# Altium schematic sheet Buttons.SchDoc
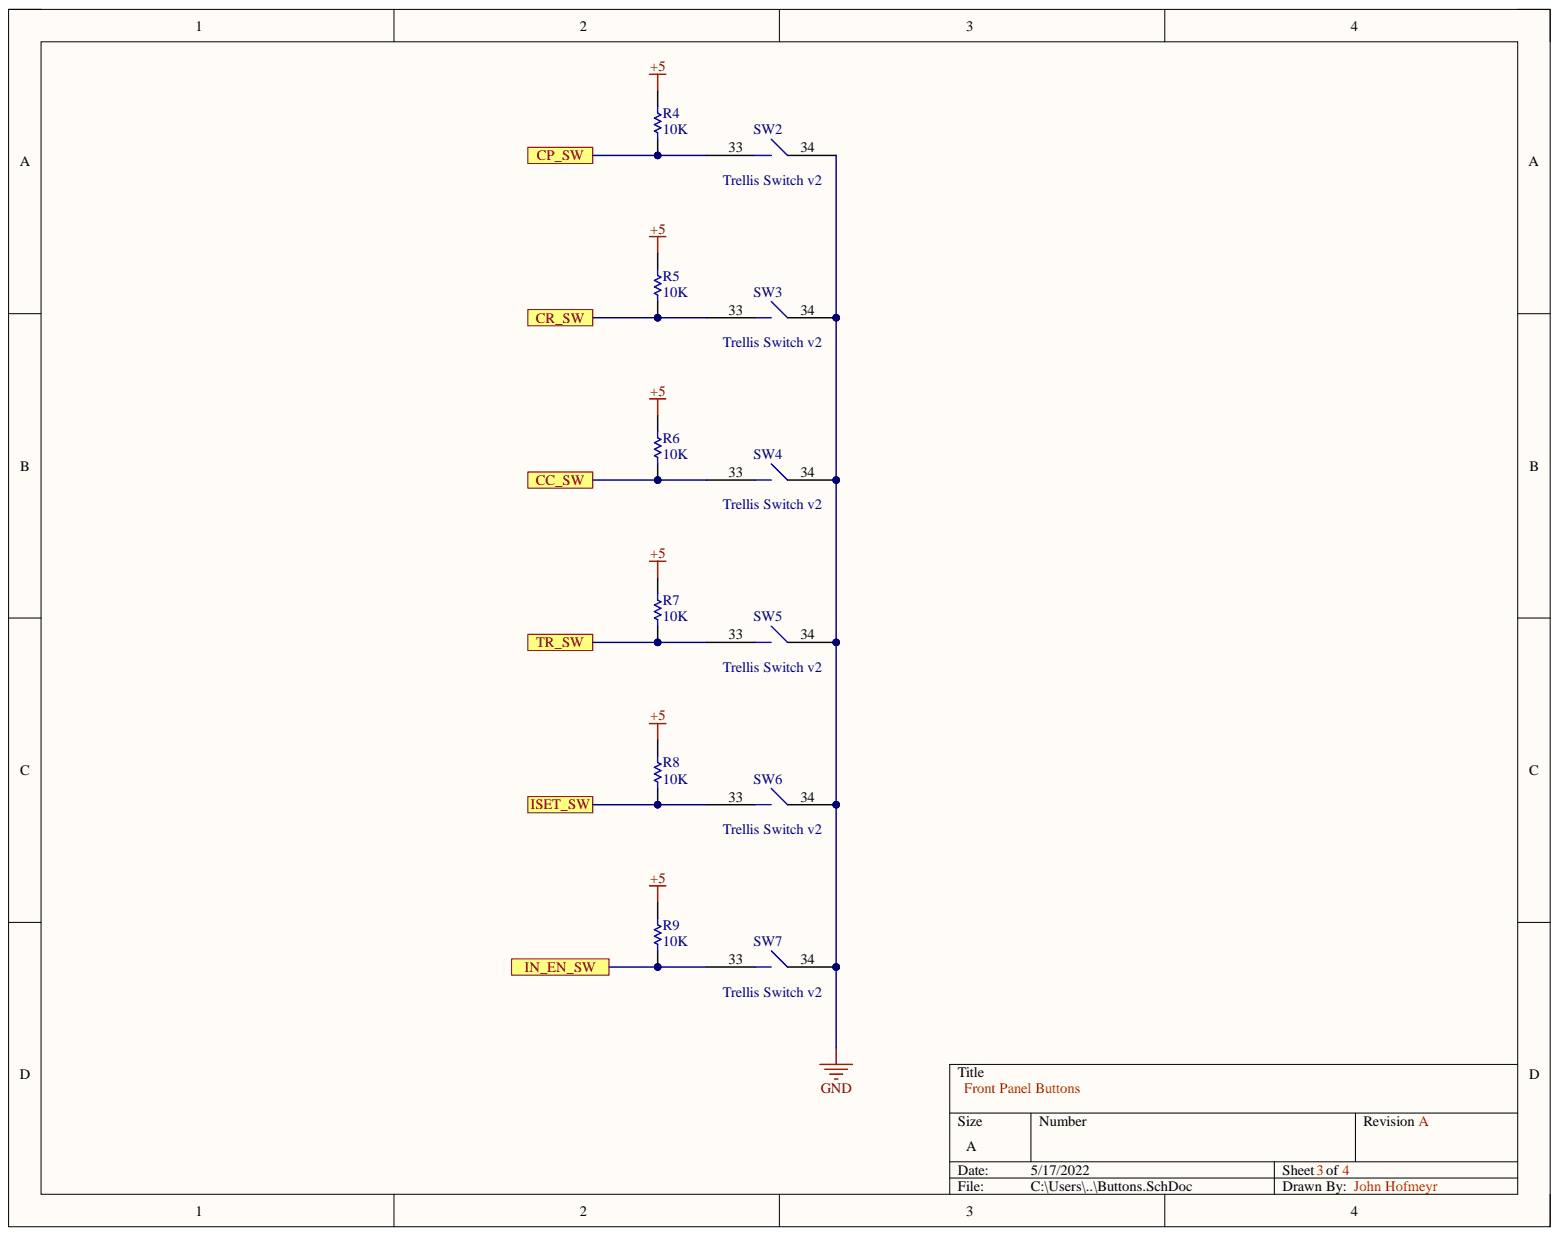
[diagram p0: Buttons.SchDoc]

## Parts
R4  10K  [RESC2013X50X40NL20T20]
R5  10K  [RESC2013X50X40NL20T20]
R6  10K  [RESC2013X50X40NL20T20]
R7  10K  [RESC2013X50X40NL20T20]
R8  10K  [RESC2013X50X40NL20T20]
R9  10K  [RESC2013X50X40NL20T20]
SW2  Trellis Switch v2  [Trellis Switch-Footprint-2]
SW3  Trellis Switch v2  [Trellis Switch-Footprint-2]
SW4  Trellis Switch v2  [Trellis Switch-Footprint-2]
SW5  Trellis Switch v2  [Trellis Switch-Footprint-2]
SW6  Trellis Switch v2  [Trellis Switch-Footprint-2]
SW7  Trellis Switch v2  [Trellis Switch-Footprint-2]

## Ports
CC_SW, CP_SW, CR_SW, IN_EN_SW, ISET_SW, TR_SW

## Nets
+5: R4.2 R5.2 R6.2 R7.2 R8.2 R9.2
GND: SW2.34 SW3.34 SW4.34 SW5.34 SW6.34 SW7.34
CC_SW: R6.1 SW4.33 ; port CC_SW
CP_SW: R4.1 SW2.33 ; port CP_SW
CR_SW: R5.1 SW3.33 ; port CR_SW
IN_EN_SW: R9.1 SW7.33 ; port IN_EN_SW
ISET_SW: R8.1 SW6.33 ; port ISET_SW
TR_SW: R7.1 SW5.33 ; port TR_SW

## Library data of the parts
R4-R9 = CMP-2001-03215-1: Manufacturer: Susumu; Part Number: RR1220P-103-D; Component Kind: Standard; Library Name: Altium Content Vault
SW2-SW7 = CMP-011-00013-4: Pad for trellis membrane switches; Component Kind: Standard; Library Name: Main Workspace
